# Altium schematic sheet B233.SchDoc(B233)
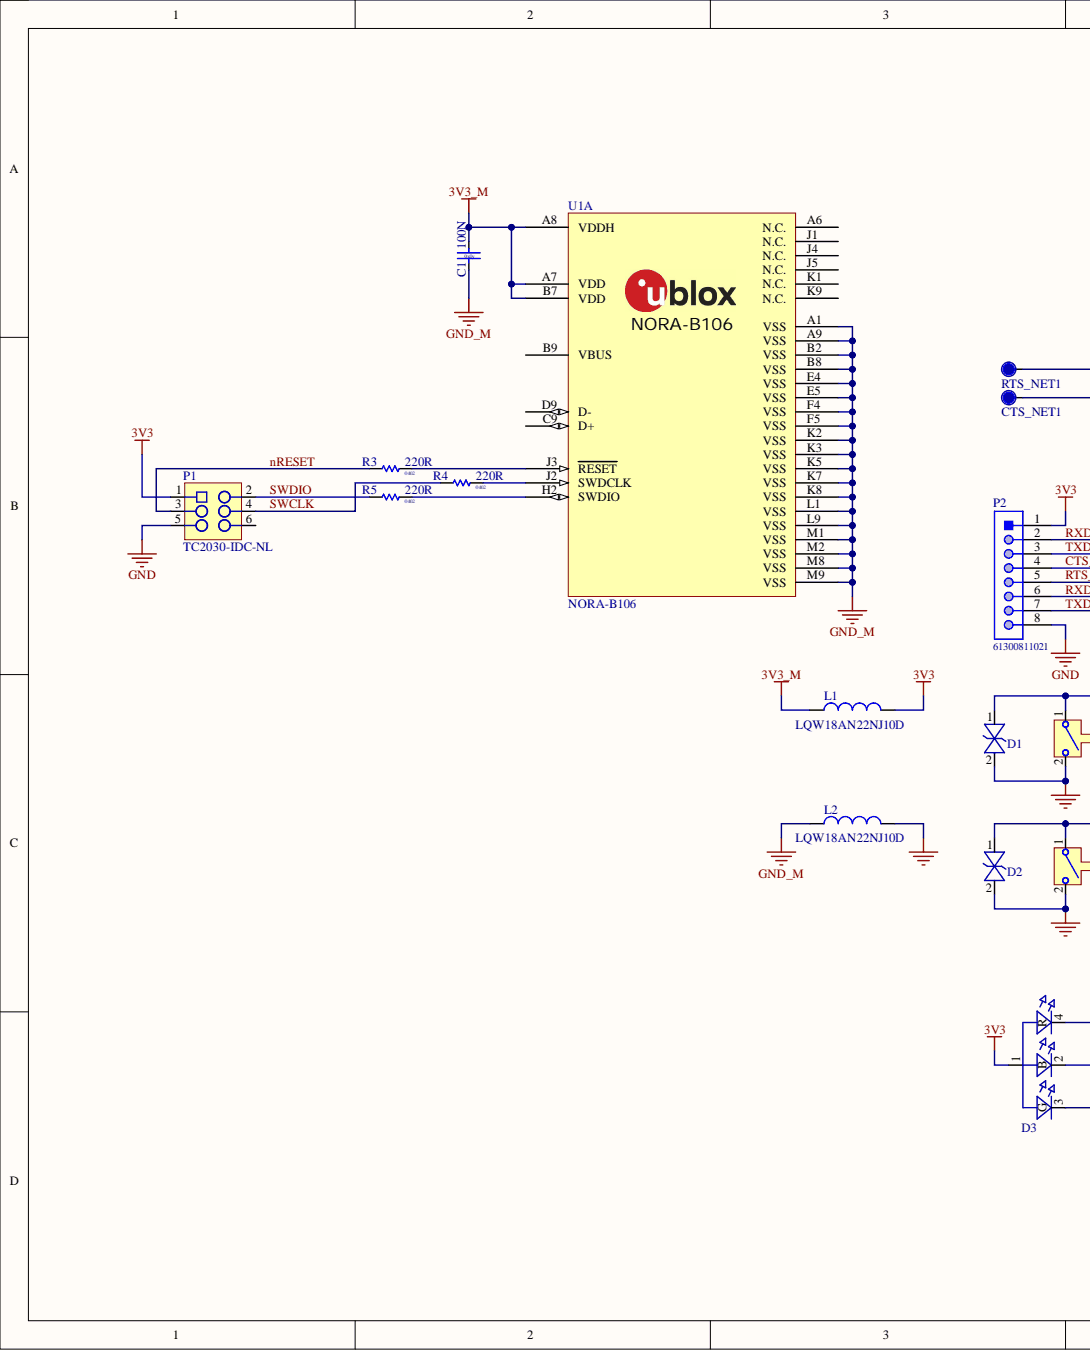
[diagram p0: B233.SchDoc, left]
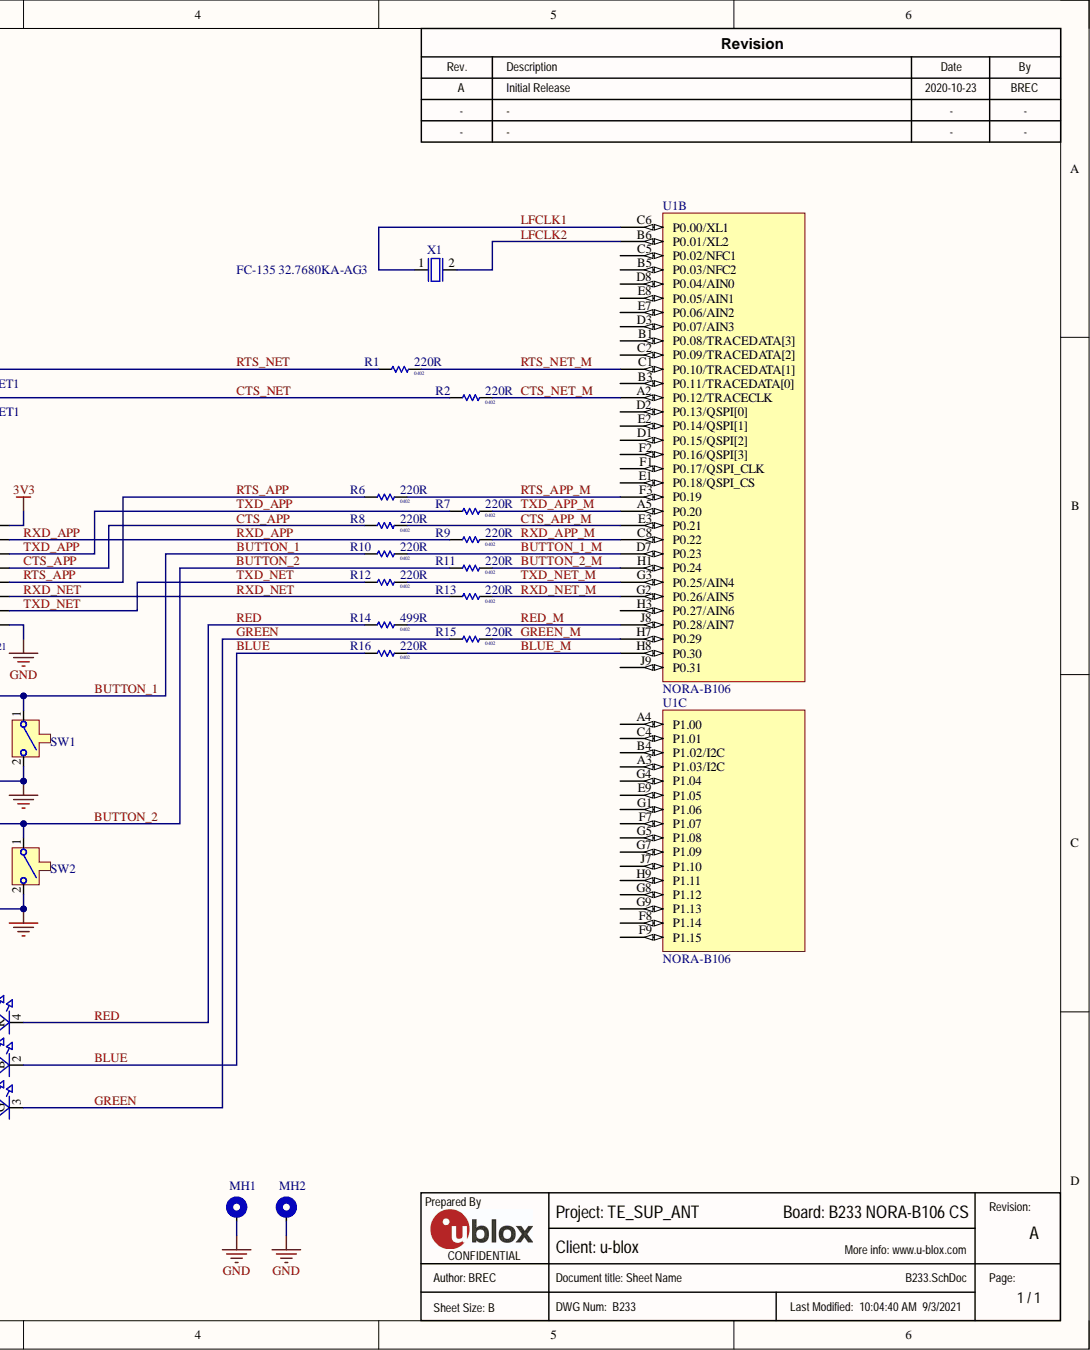
[diagram p1: B233.SchDoc, right]

## Parts
C1  value 100N  Size: 0x0y  [Cap 0402]  (hidden comment: UBXH01-2021002100N)
CTS_NET1  TP  [TP10R]  (value not drawn)
D1  110-00019  [SOD-923]  (value not drawn)
D2  110-00019  [SOD-923]  (value not drawn)
D3  115-00011  [4-PLCC]  (value not drawn)
L1  LQW18AN22NJ10D  [INDC1608X100X30LL20T10]
L2  LQW18AN22NJ10D  [INDC1608X100X30LL20T10]
MH1  MH_M2DNP  [MH_M2]  (value not drawn)
MH2  MH_M2DNP  [MH_M2]  (value not drawn)
P1  TC2030-IDC-NLDNP  [TC2030-IDC-NL]  (value not drawn)
P2  61300811021  [61300811021]
R1  value 220R  Size: 0402  [RES 0402 HD]  (hidden comment: 180-00098220R)
R2  value 220R  Size: 0402  [RES 0402 HD]  (hidden comment: 180-00098220R)
R3  value 220R  Size: 0402  [RES 0402 HD]  (hidden comment: 180-00098220R)
R4  value 220R  Size: 0402  [RES 0402 HD]  (hidden comment: 180-00098220R)
R5  value 220R  Size: 0402  [RES 0402 HD]  (hidden comment: 180-00098220R)
R6  value 220R  Size: 0402  [RES 0402 HD]  (hidden comment: 180-00098220R)
R7  value 220R  Size: 0402  [RES 0402 HD]  (hidden comment: 180-00098220R)
R8  value 220R  Size: 0402  [RES 0402 HD]  (hidden comment: 180-00098220R)
R9  value 220R  Size: 0402  [RES 0402 HD]  (hidden comment: 180-00098220R)
R10  value 220R  Size: 0402  [RES 0402 HD]  (hidden comment: 180-00098220R)
R11  value 220R  Size: 0402  [RES 0402 HD]  (hidden comment: 180-00098220R)
R12  value 220R  Size: 0402  [RES 0402 HD]  (hidden comment: 180-00098220R)
R13  value 220R  Size: 0402  [RES 0402 HD]  (hidden comment: 180-00098220R)
R14  value 499R  Size: 0402  [RES 0402 HD]  (hidden comment: 180-00031499R)
R15  value 220R  Size: 0402  [RES 0402 HD]  (hidden comment: 180-00098220R)
R16  value 220R  Size: 0402  [RES 0402 HD]  (hidden comment: 180-00098220R)
RTS_NET1  TP  [TP10R]  (value not drawn)
SW1  135-00007  [B3U-1000P]  (value not drawn)
SW2  135-00007  [B3U-1000P]  (value not drawn)
U1  NORA-B106  [NORA_LGA82R_1430X1040X180_ANT]  (parts A,B,C)
X1  100-00005  [XTAL_3215]  (value not drawn)

## Nets
3V3: D3.1 L1.2 P1.1 P2.1
3V3_M: C1.1 L1.1 U1.A7(VDD) U1.A8(VDDH) U1.B7(VDD)
GND: D1.2 D2.2 L2.2 MH1.1 MH2.1 P1.5 P2.8 SW1.2 SW2.2
GND_M: C1.2 L2.1 U1.A1(VSS) U1.A9(VSS) U1.B2(VSS) U1.B8(VSS) U1.E4(VSS) U1.E5(VSS) U1.F4(VSS) U1.F5(VSS) U1.K2(VSS) U1.K3(VSS) U1.K5(VSS) U1.K7(VSS) U1.K8(VSS) U1.L1(VSS) U1.L9(VSS) U1.M1(VSS) U1.M2(VSS) U1.M8(VSS) U1.M9(VSS)
BLUE: D3.2(B) R16.1
BLUE_M: R16.2 U1.H8(P0.30)
BUTTON_1: D1.1 R10.1 SW1.1
BUTTON_1_M: R10.2 U1.D7(P0.23)
BUTTON_2: D2.1 R11.1 SW2.1
BUTTON_2_M: R11.2 U1.H1(P0.24)
CTS_APP: P2.4 R8.1
CTS_APP_M: R8.2 U1.E3(P0.21)
CTS_NET: CTS_NET1.1 R2.1
CTS_NET_M: R2.2 U1.A2(P0.12/TRACECLK)
GREEN: D3.3(G) R15.1
GREEN_M: R15.2 U1.H7(P0.29)
LFCLK1: U1.C6(P0.00/XL1) X1.1
LFCLK2: U1.B6(P0.01/XL2) X1.2
nRESET: P1.3 R3.1
RED: D3.4(R) R14.1
RED_M: R14.2 U1.J8(P0.28/AIN7)
RTS_APP: P2.5 R6.1
RTS_APP_M: R6.2 U1.F3(P0.19)
RTS_NET: R1.1 RTS_NET1.1
RTS_NET_M: R1.2 U1.C1(P0.10/TRACEDATA[1])
RXD_APP: P2.2 R9.1
RXD_APP_M: R9.2 U1.C8(P0.22)
RXD_NET: P2.6 R13.1
RXD_NET_M: R13.2 U1.G2(P0.26/AIN5)
SWCLK: P1.4 R4.1
SWDIO: P1.2 R5.1
TXD_APP: P2.3 R7.1
TXD_APP_M: R7.2 U1.A5(P0.20)
TXD_NET: P2.7 R12.1
TXD_NET_M: R12.2 U1.G3(P0.25/AIN4)
NetR3_2: R3.2 U1.J3(RESET)
NetR4_2: R4.2 U1.J2(SWDCLK)
NetR5_2: R5.2 U1.H2(SWDIO)

Not connected: P1 6; U1 A3(P1.03/I2C) A4(P1.00) A6(N.C.) B1(P0.08/TRACEDATA[3]) B3(P0.11/TRACEDATA[0]) B4(P1.02/I2C) B5(P0.03/NFC2) B9(VBUS) C2(P0.09/TRACEDATA[2]) C4(P1.01) C5(P0.02/NFC1) C9(D+) D1(P0.15/QSPI[2]) D2(P0.13/QSPI[0]) D3(P0.07/AIN3) D8(P0.04/AIN0) D9(D-) E1(P0.18/QSPI_CS) E2(P0.14/QSPI[1]) E7(P0.06/AIN2) E8(P0.05/AIN1) E9(P1.05) F1(P0.17/QSPI_CLK) F2(P0.16/QSPI[3]) F7(P1.07) F8(P1.14) F9(P1.15) G1(P1.06) G4(P1.04) G5(P1.08) G7(P1.09) G8(P1.12) G9(P1.13) H3(P0.27/AIN6) H9(P1.11) J1(N.C.) J4(N.C.) J5(N.C.) J7(P1.10) J9(P0.31) K1(N.C.) K9(N.C.)

## Library data of the parts
C1 = UBXH01-2021002: CAP CER X5R 0402; Manufacturer: Samsung Electro-Mechanics; Manufacturer P/N: CL05A104KP5NNN; Critical: None; Database Table Name: Passive - Capacitor; Editor: roland.rust; Env: GREEN; Max Voltage: 10.0V; Mfg P/N Released: Yes; Mfg P/N Split: 0; Mfg P/N Status: Active; Perferred: PREF; Permitted Use: Volume Products; Tolerance: 10%; Automotive: None; Component Kind: Standard; Library Name: UBX_Parts_DB_Lib.DbLib; Manufacturer 2: None; Manufacturer 3: None; Manufacturer P/N 2: None; Manufacturer P/N 3: None; Max Current: None; Max Operating Temp: None; Max Power: None; Mfg P/N Datasheet: https://wiki.u-blox.com/bin/view/Generic/Docs/DocumentSummary?index=28113; Mfg P/N Datasheet 2: None; Mfg P/N Datasheet 3: None; Mfg P/N Released 2: None; Mfg P/N Released 3: None; Mfg P/N Split 2: None; Mfg P/N Split 3: None; Mfg P/N Status 2: None; Mfg P/N Status 3: None; Min Operating Temp: None; Part Number: UBXH01-2021002; Part Type: Capacitor; RefDes: C; Release Data: 2005-07-22 13:59:44; Signal Integrity: Cap Semi; Simulation: CAP; Single Source: None; UBX Wiki: https://wiki.u-blox.com/bin/view/Operations/PartDetails?index=5759; Released by: roland.rust
CTS_NET1 RTS_NET1 = TP10R: Testpoint, Round 1.0mm Dia; Added By: Jeremy D; Database Table Name: Testpoints & Pads; Added Date: 2/1/2015; Checked Date: 2/5/2015; Component Kind: Standard; DNP: DNP; Height: 0; Part Number: TP10R; Size: 1.0mm; Checked By: Paul B; Library Name: LEGACY_Rigado_AltiumLib.DbLib
D1 D2 = 110-00019: Diode, ESD9B, 3.3V, ST5G, SOD-923; Manufacturer: ON Semiconductor; Manufacturer P/N: ESD9B3.3ST5G; Part Name: Diode TVS 3.3V SOD-923; Body Size: 0.8mm x 0.6mm; Component Mounting Type: SMT; Diode Type: TVS; Item_Phase: Production; Use in new designs: Yes; Arena Link: https://app.bom.com/a/4M5DJQ9Q9SR7DV4H?v=spec.summary; Component Kind: Standard; Database Table Name: Diode; Height: 0.4mm; MFG Package: SOD-923; Package Type: SOD; Part Number: 110-00019; Part_Type: Diode; Pins: 2; Revision: 0A; Supplier 1: Arrow; Supplier Part Number 1: ESD9B3.3ST5G; Voltage: 3.3V; Library Name: Arena_Lib.DbLib
D3 = 115-00011: LED, RGB Diffused SMT, PLCC4 4 Pin; Manufacturer: Cree, Inc.; Manufacturer P/N: CLMVB-FKA-CFHEHLCBB7a363; Part Name: LED RGB SMT 2mm x 2mm; Body Size: 2.0mm x 2.0mm; Current: 20mA Red, 10mA Green, 10mA, Blue; Item_Phase: Production; Number of Circuits: 3; Use in new designs: Yes; Vf: 2V Red, 3V Green, 3V Blue; Voltage: 5V; Arena Link: https://app.bom.com/a/UCV39GZGZIHY581D?v=spec.summary; Color: RGB; Component Kind: Standard; Component Mounting Type: SMT; Database Table Name: LED; Height: 1mm; MFG Package: PLCC4; Mounting Type: Vertical; Package Type: Custom; Part Number: 115-00011; Part_Type: LED; Pins: 4; Revision: 0A; Supplier 1: Mouser; Supplier Part Number 1: 941-CLMVBFKACFHEHLCB; Library Name: Arena_Lib.DbLib
L1 L2 = CMP-2000-06600-1: Chip Inductor, 22 nH, +/-5%, 640 mA, -55 to 125 degC, 0603 (1608 Metric), RoHS, Tape and Reel; Manufacturer: Murata; Current Rating: 640mA; DC Resistance (DCR): 99mOhmMax; Frequency - Self Resonant: 3.2GHz; Frequency - Test: 100MHz; Inductance: 22nH; Material - Core: Non-Magnetic; Online Catalog: LQWSeries; Other Names: 490-6876-1; Product Training Modules: InductorProductsChipRFInductors; Q @ Freq: 42@250MHz; Shielding: Unshielded; Tolerance: ±5%; Type: Wirewound; Category: Inductors,Coils,Chokes; Component Kind: Standard; Datasheet URL: http://search.murata.co.jp/Ceramy/image/img/PDF/ENG/L0075S0080LQW18A_10.pdf; Datasheet Version: 11/2011; Family: FixedInductors; Height - Seated (Max): 0.039"(1.00mm); Library Name: Altium Content Vault; Manufacturer URL: http://www.murata.com/; Mounting Technology: SurfaceMount; Mounting Type: SurfaceMount; Operating Temperature: -55°C~125°C; Package / Case: 0603(1608Metric); Package Description: 2-Pin Surface Mount Device, Body 1.6 x 0.8 mm; Package Reference: 0603; Packaging: CutTape(CT); Series: LQW18A_10; Size / Dimension: 0.063"Lx0.031"W(1.60mmx0.80mm); Standard Package: 1
MH1 MH2 = MH_M2: 2M screw mounting hole, plated; Added By: Jeremy D; Database Table Name: Mechanical; Added Date: 7/9/2015; Component Kind: Standard; DNP: DNP; Height: 0mm; Part Number: MH_M2; Size: 4.3mm x 4.3mm; Type: Mounting Hole; Library Name: LEGACY_Rigado_AltiumLib.DbLib
P1 = TC2030-IDC-NL: CON PADS FOR CBL PLUG-OF-NAILS 6-PIN NO LEGS; Manufacturer: TAG Connect; Added By: Paul B; Database Table Name: Testpoints & Pads; Added Date: 4/22/2015; Component Kind: Standard; DNP: DNP; Height: 0; Manufacturer P/N: TC2030-IDC-NL; Part Number: TC2030-IDC-NL; Size: 6.731mm x 12.446mm; Library Name: LEGACY_Rigado_AltiumLib.DbLib
P2 = CMP-1502-01075-1: THT Angled Pin Header WR-PHD, Pitch 2.54 mm, Single Row, 8 pins; Manufacturer: Wurth Electronics; Contact Resistance: 20mOhm; Gender: Male; Pin Number: 8; Pitch: 2.54mm; Rated Current: 3A; Withstanding Voltage: 500VAC; Working Voltage: 250VAC; Component Kind: Standard; Datasheet URL: https://katalog.we-online.de/em/datasheet/6130xx11021.pdf; Library Name: 8-pin-ra-100mil.IntLib; Manufacturer Part Number: 61300811021; Manufacturer URL: http://www.we-online.de; Mounting Technology: THT; Operating Temperature: -40to105degC; Orientation: Angled; Package Reference: 61300811021; Packaging: Bag; Part Number: 61300811021; Row Number: Single; Publisher: WurthElektronik
R1-R13 R15 R16 = 180-00098: Resistor, 220ohm, 5%, 1/16W, 0402.; Manufacturer: Panasonic Electronic Components; Manufacturer P/N: ERJ-2GEJ221X; Part Name: RES SMD 220 OHM 5% 1/16W 0402; Component Mounting Type: SMT; Item_Phase: Production; Tolerance: +/- 5%; Use in new designs: Yes; Alternate Footprint Ref: RES 0402; Arena Link: https://app.bom.com/a/8Q9HNUDUDWVBJC30?v=spec.summary; Component Kind: Standard; Database Table Name: Resistor; Height: 0.4mm; Manufacturer 2: Samsung Electro-Mechanics America, Inc; Manufacturer P/N 2: RC1005J221CS; Part Number: 180-00098; Part_Type: Resistor; Pins: 2; Power: 1/16W; Revision: 0C; Signal Integrity: Res; Simulation: RESISTOR; Supplier 1: Digi-Key; Supplier 2: Digi-Key; Supplier Part Number 1: P220JCT-ND; Supplier Part Number 2: 1276-4360-1-ND; Library Name: Arena_Lib.DbLib
R14 = 180-00031: Resistor, 499ohm, 1%, 1/16W, 0402; Manufacturer: Rohm Semiconductor; Manufacturer P/N: MCR01MRTF4990; Part Name: RES SMD 499 OHM 1% 1/16W 0402; Component Mounting Type: SMT; Item_Phase: Production; Tolerance: +/- 1%; Use in new designs: Yes; Alternate Footprint Ref: RES 0402; Arena Link: https://app.bom.com/a/Q8RZ5CVCVEDU1SS5?v=spec.summary; Component Kind: Standard; Database Table Name: Resistor; Height: 0.4mm; Manufacturer 2: Samsung Electro-Mechanics America, Inc; Manufacturer P/N 2: RC1005F4990CS; Part Number: 180-00031; Part_Type: Resistor; Pins: 2; Power: 1/16W; Revision: 0D; Signal Integrity: Res; Simulation: RESISTOR; Supplier 1: Digi-Key; Supplier 2: Digi-Key; Supplier Part Number 1: RHM499CDCT-ND; Supplier Part Number 2: 1276-3992-1-ND; Library Name: Arena_Lib.DbLib
SW1 SW2 = 135-00007: Button, Momentary SPST-NO, 0.05A, 12V, 3mm x 2.5mm; Manufacturer: Omron Electronics Inc-EMC Div; Part Name: SWITCH TACTILE SPST-NO 0.05A 12V; Component Mounting Type: SMT; Current: 50mA; Item_Phase: Production; Switch/Button Circuit: SPST; Switch/Button Function: Off-Mom; Use in new designs: Yes; Voltage: 12VDC; Arena Link: https://app.bom.com/a/TBU28FYFYHGV5FQ0?v=spec.summary; Body Size: 3mm x 2.5mm; Component Kind: Standard; Database Table Name: Button; Height: 1.2mm; Manufacturer P/N: B3U-1000P; Part Number: 135-00007; Part_Type: Button; Pins: 2; Revision: 0A; Supplier 1: Digi-Key; Supplier Part Number 1: SW1020CT-ND; Library Name: Arena_Lib.DbLib
U1 = NORA-B106: u-blox NORA-B106 Bluetooth 5.2 LE module - dual core; Manufacturer P/N: NORA-B106-00B; Part Description: Stand-alone Bluetooth low energy module - dual core; Body Size: 14.3 mm x 10.4 mm; Component Kind: Standard; Height: 1.8 mm; Library Name: ubloxLib.IntLib; Manufacturer: u-blox; Part Name: NORA-B106; Part Number: NORA-B106; Part_Type: Module; Value: NORA-B106
X1 = 100-00005: XTAL, 2Pin, 32.768kHz, 7pF 20ppm; Manufacturer: Epson; Manufacturer P/N: FC-135 32.7680KA-AG3; Part Name: XTAL 32.768kHz 7pF 20ppm 3215; Body Size: 3.2mm x 1.5mm; Component Mounting Type: SMT; Database Table Name: Crystal/Oscillator; Item_Phase: Production; MFG Package: 2-SMD; Use in new designs: Yes; Arena Link: https://app.bom.com/a/L3MU07Q7Q98O5PC8?v=spec.summary; CL: 7pF; Component Kind: Standard; Frequency: 32.768kHz; Frequency Tolerance: 20ppm; Height: 0.9mm; Manufacturer 2: AVX Corporation; Manufacturer P/N 2: ST3215SB32768C0HPWBB; Part Number: 100-00005; Part_Type: Crystal/Oscillator; Pins: 2; Revision: 0C; Supplier 1: Digi-Key; Supplier 2: Digi-Key; Supplier Part Number 1: SER4086CT-ND; Supplier Part Number 2: 1253-1113-1-ND; Library Name: Arena_Lib.DbLib
